# Altium schematic sheet shematic.SchDoc
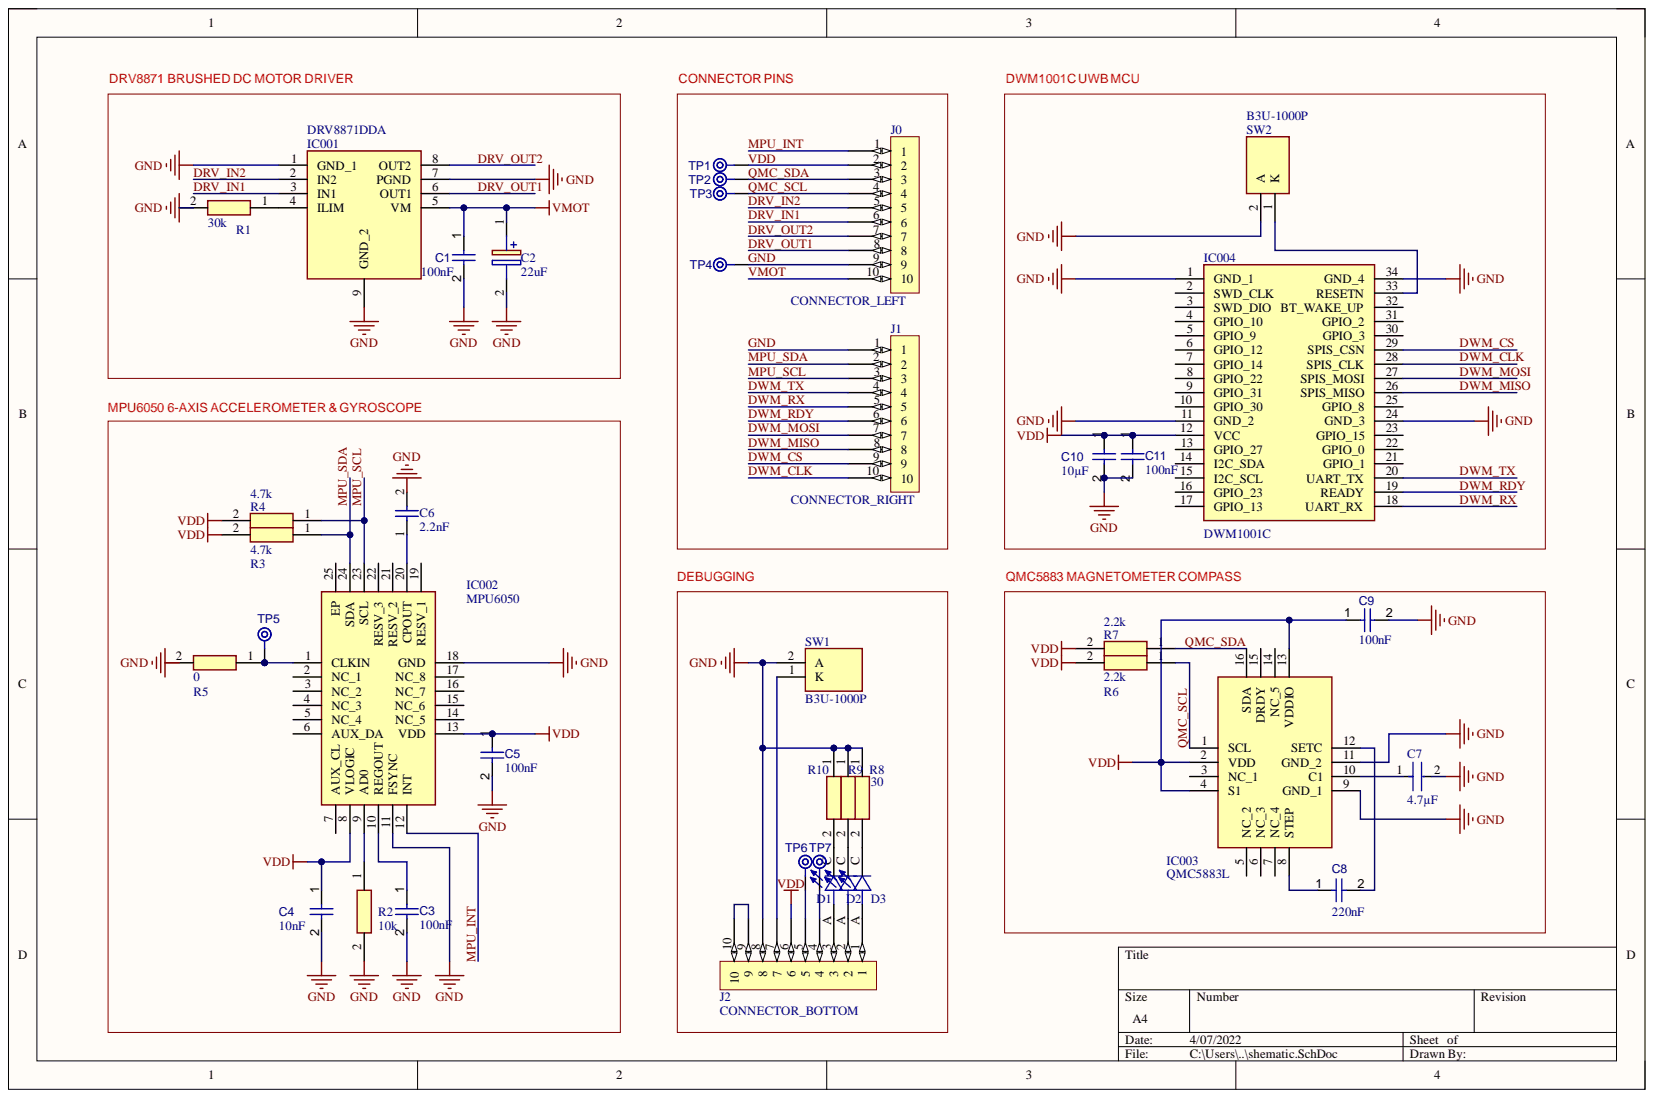
[diagram p0: shematic.SchDoc]

## Parts
C1  value 100nF  [CAPC1005X56X25LL10T15]  (hidden comment: Capacitor 0.1 uF +/- 5% 16 V 0402)
C2  22uF  [EEHZA1E101XP]
C3  value 100nF  [CAPC1005X56X25LL10T15]  (hidden comment: Capacitor 0.1 uF +/- 5% 16 V 0402)
C4  value 10nF  [CAPC0402(1005)60_L]  (hidden comment: Capacitor 10nF +/-20% 50V 0402)
C5  value 100nF  [CAPC1005X56X25LL10T15]  (hidden comment: Capacitor 0.1 uF +/- 5% 16 V 0402)
C6  2.2nF  [CAPC1608X90X35NL10T15]
C7  4.7µF  [FP-GRM155-0_1-IPC_B]
C8  value 220nF  [CAPC0402(1005)60_L]  (hidden comment: Capacitor 220nF +/-20% 10V 0402)
C9  value 100nF  [CAPC1005X56X25LL10T15]  (hidden comment: Capacitor 0.1 uF +/- 5% 16 V 0402)
C10  value 10µF  [CAPC0402(1005)60_L]  (hidden comment: Capacitor 10µF +/-40% 6.3V 0402)
C11  value 100nF  [CAPC1005X56X25LL10T15]  (hidden comment: Capacitor 0.1 uF +/- 5% 16 V 0402)
D1  LTW-C191TLA  [LEDC1608X65N]  (value not drawn)
D2  LTW-C191TLA  [LEDC1608X65N]  (value not drawn)
D3  LTW-C191TLA  [LEDC1608X65N]  (value not drawn)
IC001  DRV8871DDA  [SOIC127P600X170-9N]
IC002  MPU6050  [MPU6050]
IC003  QMC5883L  [QMC5883L]
IC004  DWM1001C  [DWM1001C]
J0  CONNECTOR_LEFT  [New footprint 1]
J1  CONNECTOR_RIGHT  [New footprint 1]
J2  CONNECTOR_BOTTOM  [New footprint 1]
R1  30k  [RESC1005X35N]
R2  10k  [RESC1005X35N]
R3  4.7k  [RESC1005X35N]
R4  4.7k  [RESC1005X35N]
R5  0  [RESC1005X35N]
R6  2.2k  [RESC1005X35N]
R7  2.2k  [RESC1005X35N]
R8  30  [RESC1005X35N]
R9  30  [RESC1005X35N]  (value not drawn)
R10  30  [RESC1005X35N]  (value not drawn)
SW1  B3U-1000P  [B3U-1000P]
SW2  B3U-1000P  [B3U-1000P]
TP1  TPAD6R  [TP06R]  (value not drawn)  (no BOM)
TP2  TPAD6R  [TP06R]  (value not drawn)  (no BOM)
TP3  TPAD6R  [TP06R]  (value not drawn)  (no BOM)
TP4  TPAD6R  [TP06R]  (value not drawn)  (no BOM)
TP5  TPAD6R  [TP06R]  (value not drawn)  (no BOM)
TP6  TPAD6R  [TP06R]  (value not drawn)  (no BOM)
TP7  TPAD6R  [TP06R]  (value not drawn)  (no BOM)

## Nets
DRV_IN1: IC001.3(IN1) J0.6
DRV_IN2: IC001.2(IN2) J0.5
DRV_OUT1: IC001.6(OUT1) J0.8
DRV_OUT2: IC001.8(OUT2) J0.7
DWM_CLK: IC004.28(SPIS_CLK) J1.10
DWM_CS: IC004.29(SPIS_CSN) J1.9
DWM_MISO: IC004.26(SPIS_MISO) J1.8
DWM_MOSI: IC004.27(SPIS_MOSI) J1.7
DWM_RDY: IC004.19(READY) J1.6
DWM_RX: IC004.18(UART_RX) J1.5
DWM_TX: IC004.20(UART_TX) J1.4
GND: C1.2 C2.2 C3.2 C4.2 C5.2 C6.2 C7.2 C9.2 C10.2 C11.2 IC001.1(GND_1) IC001.7(PGND) IC001.9(GND_2) IC002.11(FSYNC) IC002.18(GND) IC003.9(GND_1) IC003.11(GND_2) IC004.1(GND_1) IC004.11(GND_2) IC004.24(GND_3) IC004.34(GND_4) J0.9 J1.1 J2.8 R1.2 R2.2 R8.1 R9.1 R10.1 SW1.2 SW2.2 TP4.1
MPU_INT: IC002.12(INT) J0.1
MPU_SCL: IC002.23(SCL) J1.3 R4.1
MPU_SDA: IC002.24(SDA) J1.2 R3.1
QMC_SCL: IC003.1(SCL) J0.4 R6.1 TP3.1
QMC_SDA: IC003.16(SDA) J0.3 R7.1 TP2.1
VDD: C4.1 C5.1 C9.1 C10.1 C11.1 IC002.8(VLOGIC) IC002.13(VDD) IC003.2(VDD) IC003.4(S1) IC003.13(VDDIO) IC004.12(VCC) J0.2 J2.6 R3.2 R4.2 R6.2 R7.2 TP1.1
VMOT: C1.1 C2.1 IC001.5(VM) J0.10
NetC3_1: C3.1 IC002.10(REGOUT)
NetC6_1: C6.1 IC002.20(CPOUT)
NetC7_1: C7.1 IC003.10(C1)
NetC8_1: C8.1 IC003.8(STEP)
NetC8_2: C8.2 IC003.12(SETC)
NetD1_A: D1.A J2.3
NetD1_C: D1.C R10.2
NetD2_A: D2.A J2.2
NetD2_C: D2.C R9.2
NetD3_A: D3.A J2.1
NetD3_C: D3.C R8.2
NetIC001_4: IC001.4(ILIM) R1.1
NetIC002_1: IC002.1(CLKIN) R5.1 TP5.1
NetIC002_9: IC002.9(AD0) R2.1
NetIC004_33: IC004.33(RESETN) SW2.1
NetJ2_4: J2.4 TP7.1
NetJ2_5: J2.5 TP6.1
NetJ2_7: J2.7 SW1.1
NetJ2_9: J2.9 J2.10

Not connected: IC002 2(NC_1) 3(NC_2) 4(NC_3) 5(NC_4) 6(AUX_DA) 7(AUX_CL) 14(NC_5) 15(NC_6) 16(NC_7) 17(NC_8) 19(RESV_1) 21(RESV_2) 22(RESV_3) 25(EP); IC003 3(NC_1) 5(NC_2) 6(NC_3) 7(NC_4) 14(NC_5) 15(DRDY); IC004 2(SWD_CLK) 3(SWD_DIO) 4(GPIO_10) 5(GPIO_9) 6(GPIO_12) 7(GPIO_14) 8(GPIO_22) 9(GPIO_31) 10(GPIO_30) 13(GPIO_27) 14(I2C_SDA) 15(I2C_SCL) 16(GPIO_23) 17(GPIO_13) 21(GPIO_1) 22(GPIO_0) 23(GPIO_15) 25(GPIO_8) 30(GPIO_3) 31(GPIO_2) 32(BT_WAKE_UP); R5 2

## Library data of the parts
C1 C3 C5 C9 C11 = CMP-001-00001-6: Chip Capacitor, 0.1 uF, +/- 5%, 16 V, 0402 (1005 Metric); Capacitor Type: Ceramic; Voltage Rating: 16V; Case/Package: 0402; Component Kind: Standard; Max Operating Temperature: 125°C; Min Operating Temperature: -55°C; Mounting Technology: SM; Pins: 2; RoHS Compliant: True; Tolerance: 5%; Library Name: Imperial College London
C2 = EEHZC1J220XP: Capacitor Polarised; Manufacturer_Name: Panasonic; Arrow Part Number: EEHZC1J220XP; Arrow Price/Stock: https://www.arrow.com/en/products/eeh-zc1j220xp/panasonic; Component Kind: Standard; Datasheet Link: http://industrial.panasonic.com/cdbs/www-data/pdf/RDD0000/ABA0000C1234.pdf; Height: 8.3mm; Manufacturer_Part_Number: EEHZC1J220XP
C4 = CMP-001-00069-3: Chip Capacitor, 10nF +/-20%, 50V, 0402, Thickness 0.6 mm; Tolerance: 5%; Case/Package: 0402; Component Kind: Standard; Max Operating Temperature: 85°C; Min Operating Temperature: -55°C; Mounting Technology: SMT; Pins: 2; Voltage Rating: 50V; Library Name: Imperial College London
C6 = CMP-2006-02908-5: Chip Capacitor, 2.2 nF, +/- 10%, 50 V, -55 to 125 degC, 0603 (1608 Metric), RoHS, Tape and Reel; Manufacturer: Murata; Voltage Rating: 50 V; Component Kind: Standard; ComponentLink1Description: Manufacturer URL; ComponentLink1URL: http://www.murata.com/; ComponentLink2Description: Datasheet; ComponentLink2URL: http://search.murata.co.jp/Ceramy/image/img/A01X/G101/ENG/GRM188R71H222KA01-01.pdf; DatasheetVersion: 02/2016; Height: 0.8 mm; Lead Pitch: 4 mm; Length: 1.6 mm; Library Name: Altium Content Vault; Max Operating Temperature: 125 degC; Min Operating Temperature: -55 degC; Mount: Surface Mount; Mounting Technology: Surface Mount; Package Quantity: 4000; PackageDescription: 2-Pin Surface Mount Device, Body 1.6 x 0.8 mm; PackageReference: 0603; Packaging: Tape and Reel; Pins: 2; REACH SVHC: No SVHC; RoHSCompliant: true
C7 = CMP-2008-04549-2: Chip Multilayer Ceramic Capacitors for General Purpose, 0402, 4.7uF, X5R, 15%, 20%, 6.3V; Capacitance: 4.7uF; Case Code (Imperial): 0402; Case Code (Metric): 1005; Case/Package: 0402; Component Kind: Standard; Contact Plating: Tin; Depth: 0.5mm; Dielectric: X5R; Height: 0.5mm; Lead Free: Lead Free; Length: 1mm; Library Name: Altium Content Vault; Max Operating Temperature: 85°C; Min Operating Temperature: -55°C; Mount: Surface Mount; Package Quantity: 10000; Packaging: Tape and Reel; REACH SVHC: No SVHC; Termination: SMD/SMT; Thickness: 0.022inch; Tolerance: 20%; Voltage: 6.3V; Voltage Rating: 6.3V; Voltage Rating (DC): 6.3V; Weight: 0.000212oz; Width: 0.02inch
C8 = CMP-001-00057-3: Chip Capacitor, 220nF +/-20%, 10V, 0402, Thickness 0.6 mm; Tolerance: 10%; Case/Package: 0402; Component Kind: Standard; Max Operating Temperature: 85°C; Min Operating Temperature: -55°C; Mounting Technology: SMT; Pins: 2; Voltage Rating: 10V; Library Name: Imperial College London
C10 = CMP-001-00074-3: Chip Capacitor, 10µF +/-40%, 6.3V, 0402, Thickness 0.6 mm; Tolerance: 10%; Case/Package: 0402; Component Kind: Standard; Max Operating Temperature: 85°C; Min Operating Temperature: -55°C; Mounting Technology: SMT; Pins: 2; Voltage Rating: 6.3V; Library Name: Imperial College London
D1-D3 = LTW-C191TLA: White LED Indication - Discrete 3.1V 0603 _1608 Metric_; MF: Lite-On; Availability: Unavailable; Component Kind: Standard; Library Name: LTW-C191TLA-3D.IntLib; MP: LTW-C191TLA; Package: 0603 Lite-On; Price: None; SnapEDA_Link: https://www.snapeda.com/parts/LTW-C191TLA/Lite-On/view-part/538855/?ref=snap
IC001 = DRV8871DDA: DRV8871 Brushed DC Motor Driver; Manufacturer_Name: Texas Instruments; Arrow Part Number: DRV8871DDA; Arrow Price/Stock: https://www.arrow.com/en/products/drv8871dda/texas-instruments?region=nac; Component Kind: Standard; Datasheet Link: http://www.ti.com/lit/ds/symlink/drv8871.pdf; Height: 1.7mm; Manufacturer_Part_Number: DRV8871DDA; Mouser Part Number: 595-DRV8871DDA; Mouser Price/Stock: https://www.mouser.co.uk/ProductDetail/Texas-Instruments/DRV8871DDA?qs=Fobv33ltQGiluwfB07aSBw%3D%3D
IC002 = MPU-6050: Integrated Circuit; Manufacturer_Name: TDK; Arrow Part Number: MPU-6050; Arrow Price/Stock: https://www.arrow.com/en/products/mpu-6050/invensense?region=nac; Component Kind: Standard; Datasheet Link: https://product.tdk.com/system/files/dam/doc/product/sensor/mortion-inertial/imu/data_sheet/mpu-6000-datasheet1.pdf; Height: 0.95mm; Manufacturer_Part_Number: MPU-6050; Mouser Part Number: 410-MPU-6050; Mouser Price/Stock: https://www.mouser.co.uk/ProductDetail/TDK-InvenSense/MPU-6050?qs=u4fy%2FsgLU9O14B5JgyQFvg%3D%3D
IC003 = QMC5883L: Integrated Circuit; Manufacturer_Name: QST; Component Kind: Standard; Datasheet Link: https://datasheet.lcsc.com/lcsc/2012221837_QST-QMC5883L_C976032.pdf; Height: 1.02mm; Manufacturer_Part_Number: QMC5883L
IC004 = DWM1001C: Integrated Circuit; Manufacturer_Name: Qorvo; Component Kind: Standard; Datasheet Link: https://www.decawave.com/sites/default/files/dwm1001_datasheet.pdf; Height: 3.4mm; Manufacturer_Part_Number: DWM1001C; Mouser Part Number: 772-DWM1001C; Mouser Price/Stock: https://www.mouser.co.uk/ProductDetail/Qorvo/DWM1001C?qs=sPbYRqrBIVlN736UO79ajA%3D%3D
J0-J2 = DRONE-001-2: Custom pad layout for drone sensor board; Component Kind: Standard; Library Name: Imperial College London
R1 = CPF0402B30K1E1: Resistor; Manufacturer_Name: TE Connectivity; Component Kind: Standard; Datasheet Link: http://www.farnell.com/datasheets/1786775.pdf; Height: 0.35mm; Manufacturer_Part_Number: CPF0402B30K1E1; Mouser Part Number: 279-CPF0402B30K1E1; Mouser Price/Stock: https://www.mouser.com/Search/Refine.aspx?Keyword=279-CPF0402B30K1E1
R2 R6-R10 = CPF0402B10K5E: Resistor; Manufacturer_Name: TE Connectivity; Arrow Part Number: CPF0402B10K5E; Arrow Price/Stock: https://www.arrow.com/en/products/cpf0402b10k5e/te-connectivity?region=nac; Component Kind: Standard; Datasheet Link: http://www.farnell.com/datasheets/1786775.pdf; Height: 0.35mm; Manufacturer_Part_Number: CPF0402B10K5E; Mouser Part Number: N/A; Mouser Price/Stock: https://www.mouser.co.uk/ProductDetail/TE-Connectivity-Neohm/CPF0402B10K5E?qs=chTDxNqvsymW8ByynyC5nA%3D%3D
R3 = CPF0402B10K5E: Resistor±1% 1/16W Thick Film Resistors 50V ±100ppm/℃ -55℃~+155℃ 4.7kΩ 0402 Chip Resistor - Surface Mount ROHS; Manufacturer_Name: TE Connectivity; Arrow Part Number: CPF0402B10K5E; Arrow Price/Stock: https://www.arrow.com/en/products/cpf0402b10k5e/te-connectivity?region=nac; Component Kind: Standard; Datasheet Link: http://www.farnell.com/datasheets/1786775.pdf; Height: 0.35mm; Manufacturer_Part_Number: CPF0402B10K5E; Mouser Part Number: N/A; Mouser Price/Stock: https://www.mouser.co.uk/ProductDetail/TE-Connectivity-Neohm/CPF0402B10K5E?qs=chTDxNqvsymW8ByynyC5nA%3D%3D
R4 = CPF0402B10K5E: ±1% 1/16W Thick Film Resistors 50V ±100ppm/℃ -55℃~+155℃ 4.7kΩ 0402 Chip Resistor - Surface Mount ROHS; Manufacturer_Name: TE Connectivity; Arrow Part Number: CPF0402B10K5E; Arrow Price/Stock: https://www.arrow.com/en/products/cpf0402b10k5e/te-connectivity?region=nac; Component Kind: Standard; Datasheet Link: http://www.farnell.com/datasheets/1786775.pdf; Height: 0.35mm; Manufacturer_Part_Number: CPF0402B10K5E; Mouser Part Number: N/A; Mouser Price/Stock: https://www.mouser.co.uk/ProductDetail/TE-Connectivity-Neohm/CPF0402B10K5E?qs=chTDxNqvsymW8ByynyC5nA%3D%3D
R5 = CPF0402B10K5E: ±1% 1/16W Thick Film Resistors 50V ±800ppm/℃ -55℃~+155℃ 0Ω 0402 Chip Resistor - Surface Mount ROHS; Manufacturer_Name: TE Connectivity; Arrow Part Number: CPF0402B10K5E; Arrow Price/Stock: https://www.arrow.com/en/products/cpf0402b10k5e/te-connectivity?region=nac; Component Kind: Standard; Datasheet Link: http://www.farnell.com/datasheets/1786775.pdf; Height: 0.35mm; Manufacturer_Part_Number: CPF0402B10K5E; Mouser Part Number: N/A; Mouser Price/Stock: https://www.mouser.co.uk/ProductDetail/TE-Connectivity-Neohm/CPF0402B10K5E?qs=chTDxNqvsymW8ByynyC5nA%3D%3D
SW1 SW2 = B3U-1000P: Switch; Manufacturer_Name: Omron Electronics; Arrow Part Number: B3U-1000P; Arrow Price/Stock: https://www.arrow.com/en/products/b3u1000p/omron?region=nac; Component Kind: Standard; Datasheet Link: https://www.omron.com/ecb/products/pdf/en-b3u.pdf; Manufacturer_Part_Number: B3U-1000P; Mouser Part Number: 653-B3U-1000P; Mouser Price/Stock: https://www.mouser.co.uk/ProductDetail/Omron-Electronics/B3U-1000P?qs=AO7BQMcsEu4ip80xyf2FwA%3D%3D
TP1-TP7 = CMP-00028-00002-2: 0.6mm round; Pins: 1; Library Name: Imperial College London
